# Altium schematic sheet 268f.SchDoc
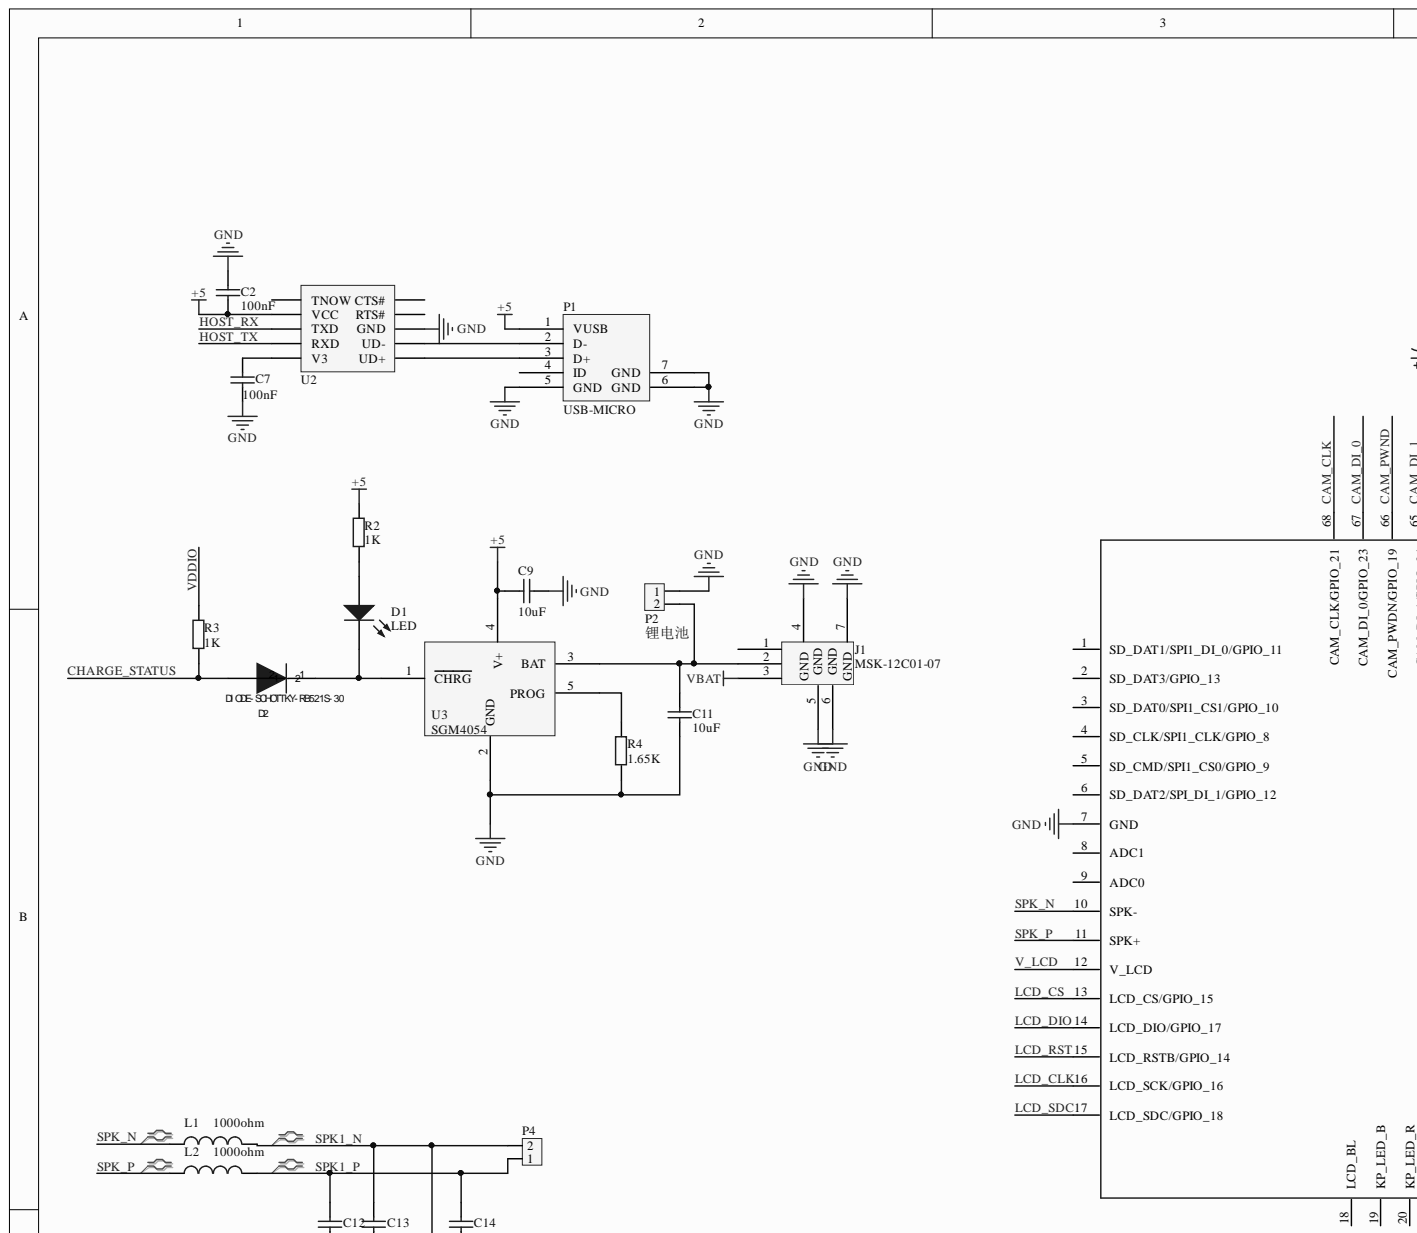
[diagram p0: 268f.SchDoc, top left]
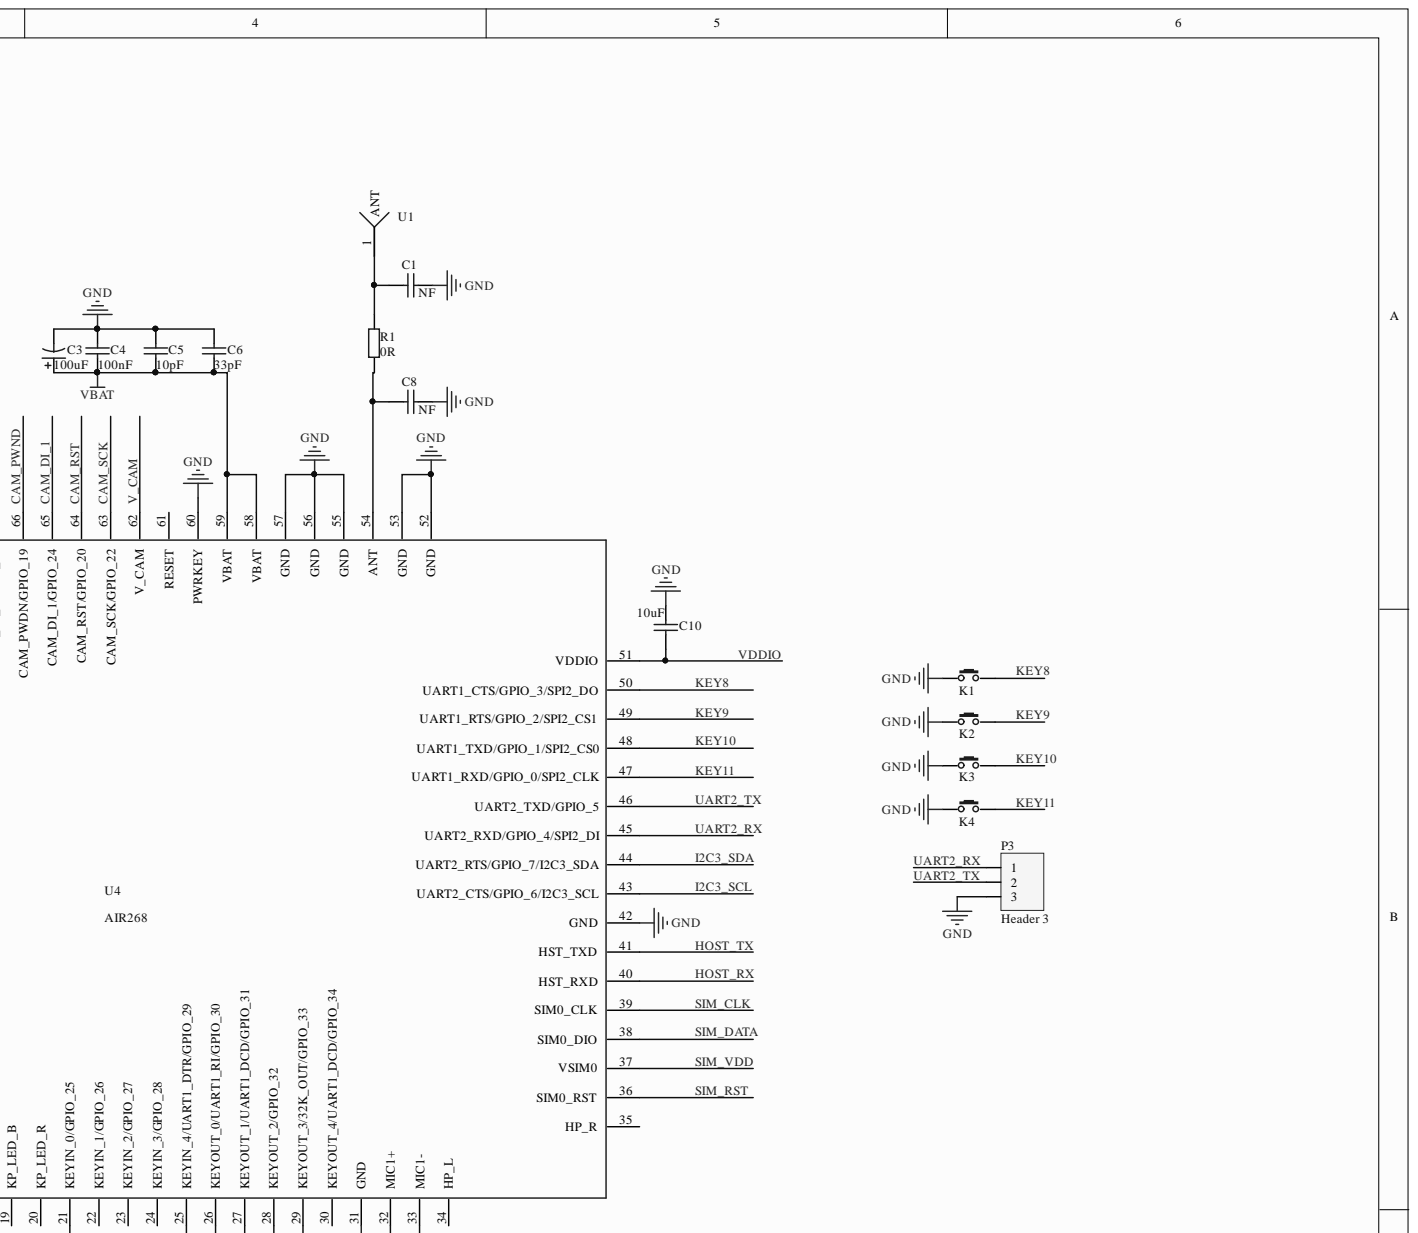
[diagram p1: 268f.SchDoc, top right]
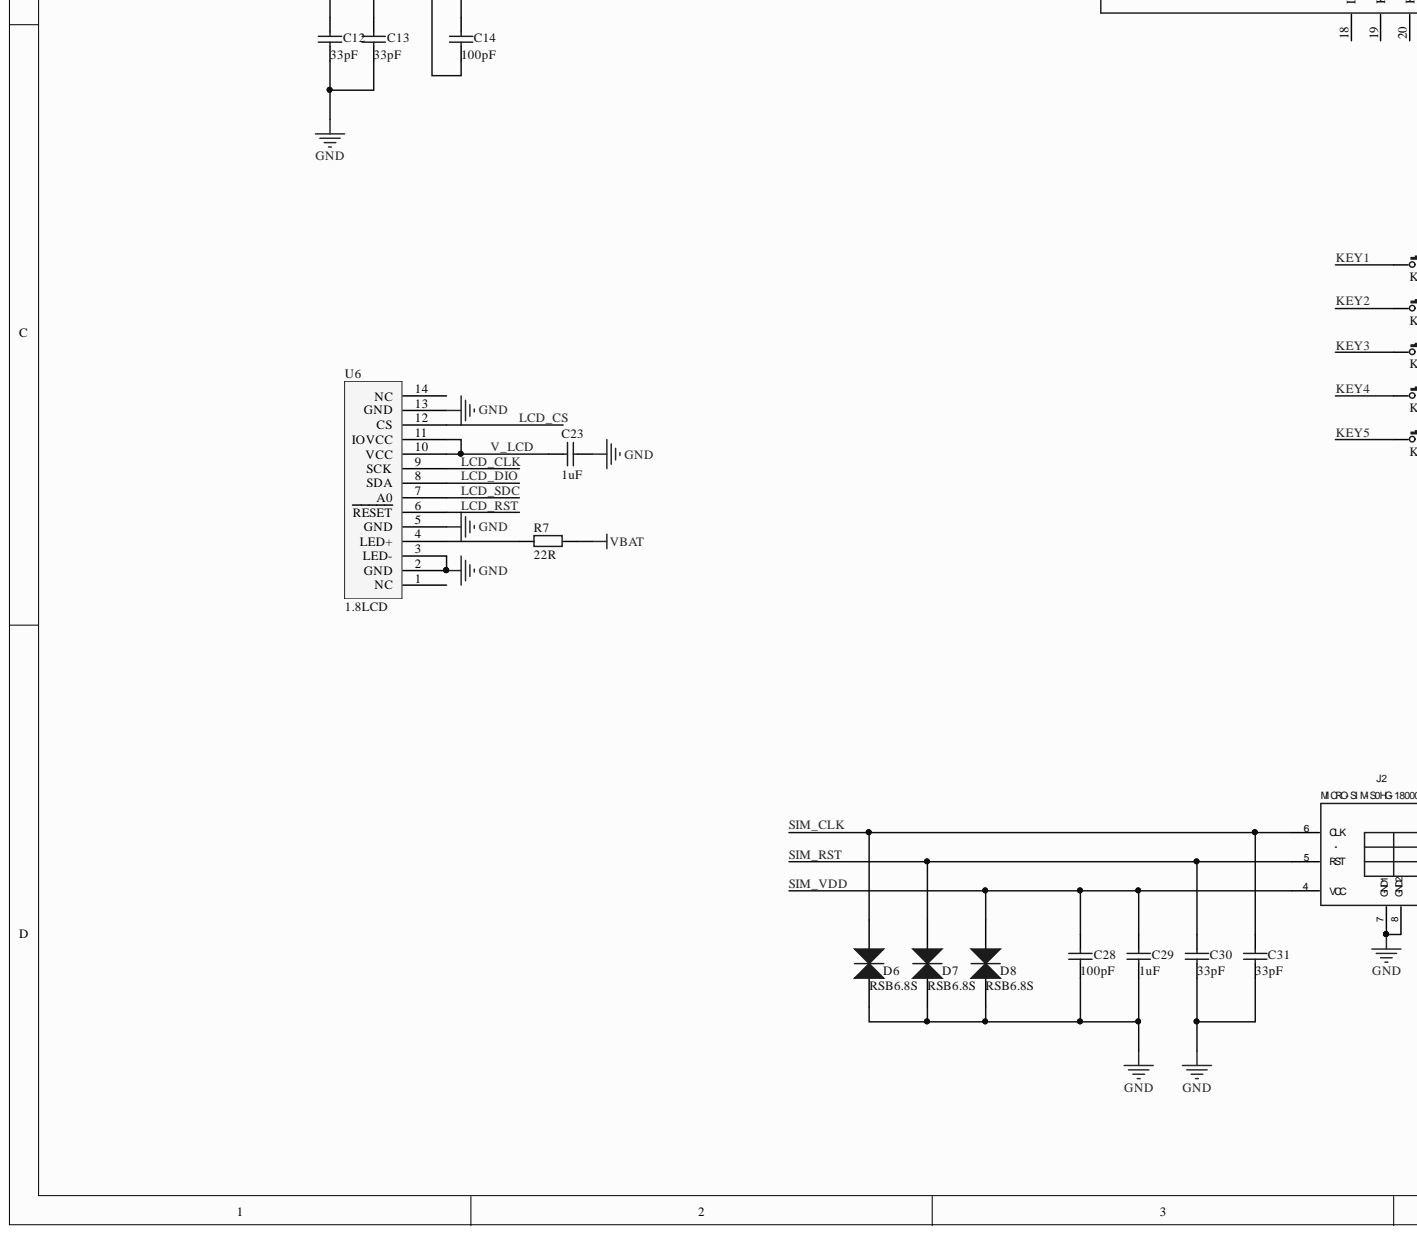
[diagram p2: 268f.SchDoc, bottom left]
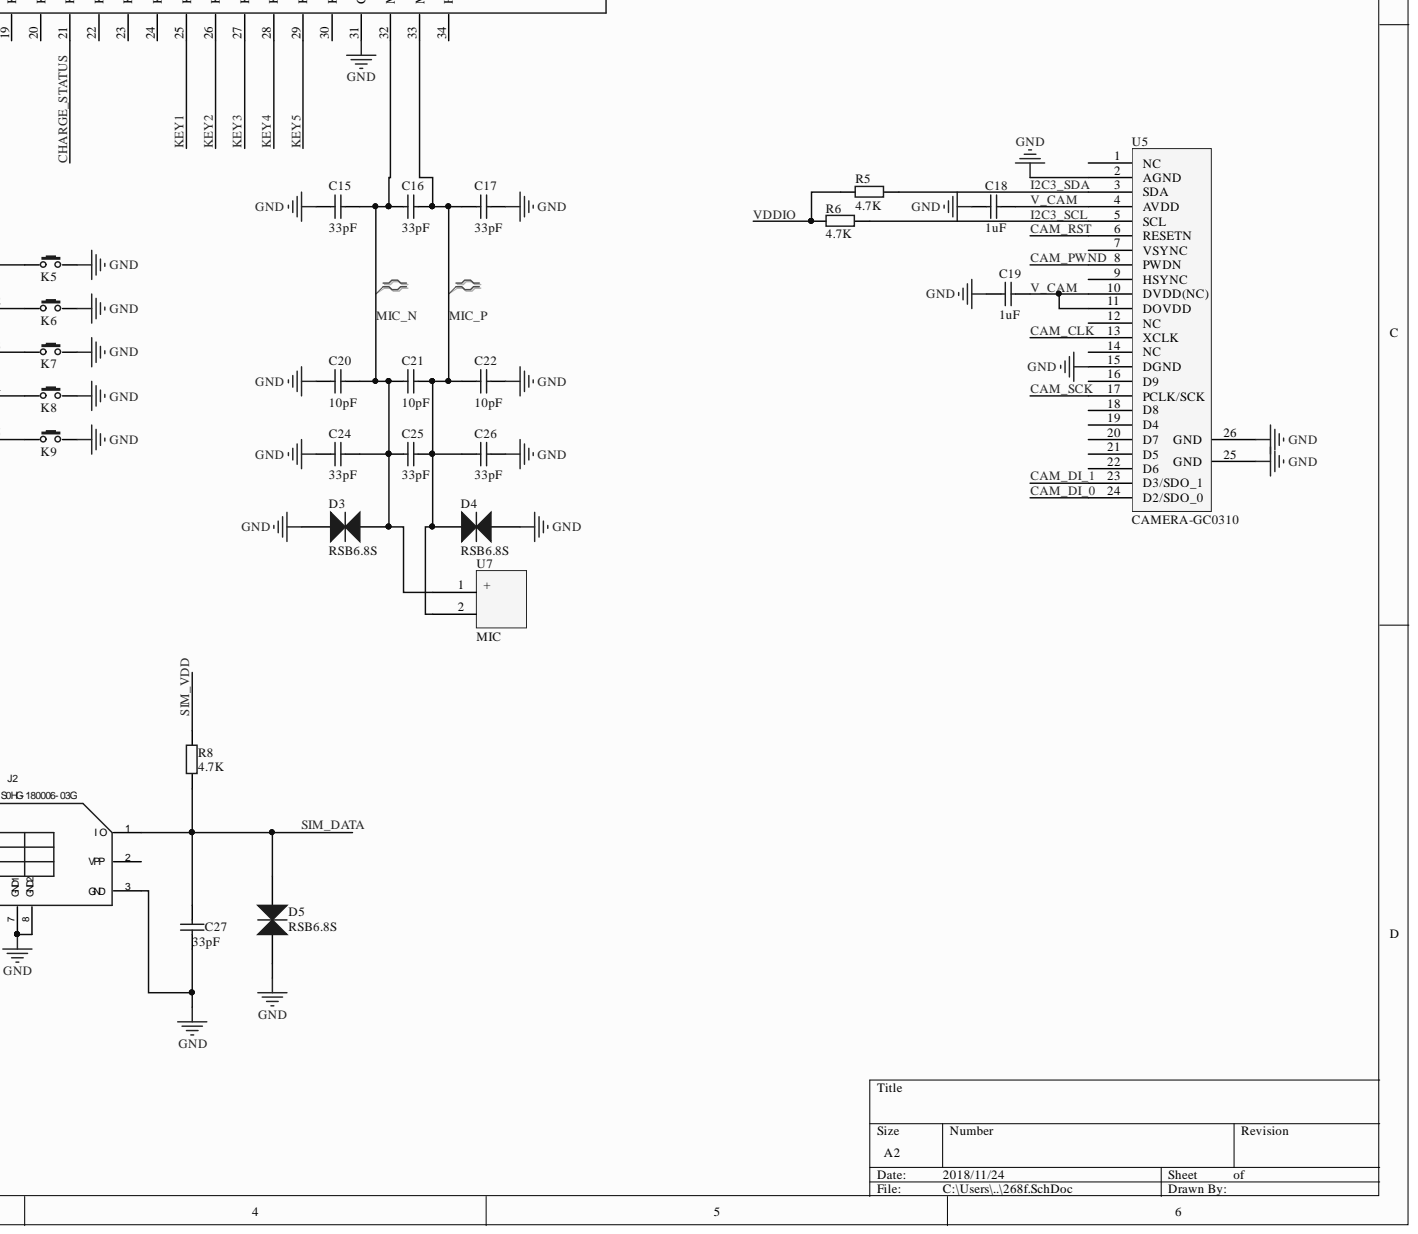
[diagram p3: 268f.SchDoc, bottom right]

## Parts
C1  value NF  [SC0402]  (hidden comment: 无极性电容)
C2  value 100nF  [SC0402]  (hidden comment: 无极性电容)
C3  value 100uF  [CAPM-B-3528X21N]  (hidden comment: 贴片铝/钽电容)
C4  value 100nF  [SC0402]  (hidden comment: 无极性电容)
C5  value 10pF  [SC0402]  (hidden comment: 无极性电容)
C6  value 33pF  [SC0402]  (hidden comment: 无极性电容)
C7  value 100nF  [SC0402]  (hidden comment: 无极性电容)
C8  value NF  [SC0402]  (hidden comment: 无极性电容)
C9  value 10uF  [SC0402]  (hidden comment: 无极性电容)
C10  value 10uF  [SC0402]  (hidden comment: 无极性电容)
C11  value 10uF  [SC0402]  (hidden comment: 无极性电容)
C12  value 33pF  [SC0402]  (hidden comment: 无极性电容)
C13  value 33pF  [SC0402]  (hidden comment: 无极性电容)
C14  value 100pF  [SC0402]  (hidden comment: 无极性电容)
C15  value 33pF  [SC0402]  (hidden comment: 无极性电容)
C16  value 33pF  [SC0402]  (hidden comment: 无极性电容)
C17  value 33pF  [SC0402]  (hidden comment: 无极性电容)
C18  value 1uF  [SC0402]  (hidden comment: 无极性电容)
C19  value 1uF  [SC0402]  (hidden comment: 无极性电容)
C20  value 10pF  [SC0402]  (hidden comment: 无极性电容)
C21  value 10pF  [SC0402]  (hidden comment: 无极性电容)
C22  value 10pF  [SC0402]  (hidden comment: 无极性电容)
C23  value 1uF  [SC0402]  (hidden comment: 无极性电容)
C24  value 33pF  [SC0402]  (hidden comment: 无极性电容)
C25  value 33pF  [SC0402]  (hidden comment: 无极性电容)
C26  value 33pF  [SC0402]  (hidden comment: 无极性电容)
C27  value 33pF  [SC0402]  (hidden comment: 无极性电容)
C28  value 100pF  [SC0402]  (hidden comment: 无极性电容)
C29  value 1uF  [SC0402]  (hidden comment: 无极性电容)
C30  value 33pF  [SC0402]  (hidden comment: 无极性电容)
C31  value 33pF  [SC0402]  (hidden comment: 无极性电容)
D1  LED  [LED_0603]
D2  DIODE-SCHOTTKY-RB521S-30  [SOD523(RB521S)]
D3  RSB6.8S  [SOD523(RB521S)]
D4  RSB6.8S  [SOD523(RB521S)]
D5  RSB6.8S  [SOD523(RB521S)]
D6  RSB6.8S  [SOD523(RB521S)]
D7  RSB6.8S  [SOD523(RB521S)]
D8  RSB6.8S  [SOD523(RB521S)]
J1  MSK-12C01-07  [MSK-12C01-07]
J2  MICRO-SIM-S0HG-180006-03G  [S0HG-180006-03G]
K1  SW-2A_1  [SW-2 贴片]  (value not drawn)
K2  SW-2A_1  [SW-2 贴片]  (value not drawn)
K3  SW-2A_1  [SW-2 贴片]  (value not drawn)
K4  SW-2A_1  [SW-2 贴片]  (value not drawn)
K5  SW-2A_1  [SW-2 贴片]  (value not drawn)
K6  SW-2A_1  [SW-2 贴片]  (value not drawn)
K7  SW-2A_1  [SW-2 贴片]  (value not drawn)
K8  SW-2A_1  [SW-2 贴片]  (value not drawn)
K9  SW-2A_1  [SW-2 贴片]  (value not drawn)
L1  value 1000ohm  [SL0402]  (hidden comment: LND)
L2  value 1000ohm  [SL0402]  (hidden comment: LND)
P1  USB-MICRO  [Micro USB]
P2  name: 锂电池  [2pad]  (hidden comment: Header 2)
P3  Header 3  [3pin-2.54插针]
P4  Header 2  [2pad]  (value not drawn)
R1  value 0R  [SC0402]  (hidden comment: 贴片电阻)
R2  value 1K  [SC0402]  (hidden comment: 贴片电阻)
R3  value 1K  [SC0402]  (hidden comment: 贴片电阻)
R4  value 1.65K  [SC0402]  (hidden comment: 贴片电阻)
R5  value 4.7K  [SC0402]  (hidden comment: 贴片电阻)
R6  value 4.7K  [SC0402]  (hidden comment: 贴片电阻)
R7  value 22R  [0603AR]  (hidden comment: 贴片电阻)
R8  value 4.7K  [SC0402]  (hidden comment: 贴片电阻)
U1  ANT-01  [ant-01]  (value not drawn)
U2  CH340E  [TSSOP50P490X110-10N]  (value not drawn)
U3  SGM4054  [SOT23-5 - duplicate]
U4  AIR268  [AIR268]
U5  CAMERA-GC0310  [FH12-24S-0.5SH]
U6  1.8LCD  [1.8LCD]
U7  MIC  [MIC]

## Nets
+5: C2.2 C9.2 P1.1(VUSB) R2.2 U2.7(VCC) U3.4(V+)
GND: C1.2 C2.1 C3.2 C4.2 C5.2 C6.2 C7.1 C8.2 C9.1 C10.2 C11.2 C12.1 C13.1 C15.2 C17.1 C18.1 C19.1 C20.1 C22.2 C23.1 C24.2 C26.1 C27.1 C28.1 C29.1 C30.1 C31.1 D3.1 D4.2 D5.1 D6.1 D7.1 D8.1 J1.4 J1.5(GND) J1.6(GND) J1.7(GND) J2.3(GND) J2.7(GND1) J2.8(GND2) K1.1 K2.1 K3.1 K4.1 K5.2 K6.2 K7.2 K8.2 K9.2 P1.5(GND) P1.6(GND) P1.7(GND) P2.1 P3.3 R4.1 U2.3(GND) U3.2(GND) U4.7(GND) U4.31(GND) U4.42(GND) U4.52(GND) U4.53(GND) U4.55(GND) U4.56(GND) U4.57(GND) U4.60(PWRKEY) U4.69 U4.70 U4.71 U4.72 U4.73 U4.74 U4.75 U5.2(AGND) U5.15(DGND) U5.25(GND) U5.26(GND) U6.2(GND) U6.3(LED-) U6.5(GND) U6.13(GND)
VBAT: C3.1 C4.1 C5.1 C6.1 J1.3 R7.1 U4.58(VBAT) U4.59(VBAT)
CAM_CLK: U4.68(CAM_CLK/GPIO_21) U5.13(XCLK)
CAM_DI_0: U4.67(CAM_DI_0/GPIO_23) U5.24(D2/SDO_0)
CAM_DI_1: U4.65(CAM_DI_1/GPIO_24) U5.23(D3/SDO_1)
CAM_PWND: U4.66(CAM_PWDN/GPIO_19) U5.8(PWDN)
CAM_RST: U4.64(CAM_RST/GPIO_20) U5.6(RESETN)
CAM_SCK: U4.63(CAM_SCK/GPIO_22) U5.17(PCLK/SCK)
CHARGE_STATUS: D2.2 R3.1 U4.21(KEYIN_0/GPIO_25)
HOST_RX: U2.8(TXD) U4.40(HST_RXD)
HOST_TX: U2.9(RXD) U4.41(HST_TXD)
I2C3_SCL: R6.1 U4.43(UART2_CTS/GPIO_6/I2C3_SCL) U5.5(SCL)
I2C3_SDA: R5.1 U4.44(UART2_RTS/GPIO_7/I2C3_SDA) U5.3(SDA)
KEY1: K5.1 U4.25(KEYIN_4/UART1_DTR/GPIO_29)
KEY2: K6.1 U4.26(KEYOUT_0/UART1_RI/GPIO_30)
KEY3: K7.1 U4.27(KEYOUT_1/UART1_DCD/GPIO_31)
KEY4: K8.1 U4.28(KEYOUT_2/GPIO_32)
KEY5: K9.1 U4.29(KEYOUT_3/32K_OUT/GPIO_33)
KEY8: K1.2 U4.50(UART1_CTS/GPIO_3/SPI2_DO)
KEY9: K2.2 U4.49(UART1_RTS/GPIO_2/SPI2_CS1)
KEY10: K3.2 U4.48(UART1_TXD/GPIO_1/SPI2_CS0)
KEY11: K4.2 U4.47(UART1_RXD/GPIO_0/SPI2_CLK)
LCD_CLK: U4.16(LCD_SCK/GPIO_16) U6.9(SCK)
LCD_CS: U4.13(LCD_CS/GPIO_15) U6.12(CS)
LCD_DIO: U4.14(LCD_DIO/GPIO_17) U6.8(SDA)
LCD_RST: U4.15(LCD_RSTB/GPIO_14) U6.6(RESET)
LCD_SDC: U4.17(LCD_SDC/GPIO_18) U6.7(A0)
MIC_N: C15.1 C16.2 C20.2 C21.1 C24.1 C25.2 D3.2 U4.32(MIC1+) U7.1
MIC_P: C16.1 C17.2 C21.2 C22.1 C25.1 C26.2 D4.1 U4.33 U7.2
SIM_CLK: C31.2 D6.2 J2.6(CLK) U4.39(SIM0_CLK)
SIM_DATA: C27.2 D5.2 J2.1(IO) R8.1 U4.38(SIM0_DIO)
SIM_RST: C30.2 D7.2 J2.5(RST) U4.36(SIM0_RST)
SIM_VDD: C28.2 C29.2 D8.2 J2.4(VCC) R8.2 U4.37(VSIM0)
SPK1_N: C13.2 C14.1 L1.2 P4.2
SPK1_P: C12.2 C14.2 L2.2 P4.1
SPK_N: L1.1 U4.10(SPK-)
SPK_P: L2.1 U4.11(SPK+)
UART2_RX: P3.1 U4.45(UART2_RXD/GPIO_4/SPI2_DI)
UART2_TX: P3.2 U4.46(UART2_TXD/GPIO_5)
V_CAM: C18.2 C19.2 U4.62(V_CAM) U5.4(AVDD) U5.10(DVDD(NC)) U5.11(DOVDD)
V_LCD: C23.2 U4.12(V_LCD) U6.10(VCC) U6.11(IOVCC)
VDDIO: C10.1 R3.2 R5.2 R6.2 U4.51(VDDIO)
NetC1_1: C1.1 R1.2 U1.1
NetC7_2: C7.2 U2.10(V3)
NetC8_1: C8.1 R1.1 U4.54(ANT)
NetC11_1: C11.1 J1.2 P2.2 U3.3(BAT)
NetD1_1: D1.1 R2.1
NetD1_2: D1.2 D2.1 U3.1(CHRG)
NetP1_2: P1.2(D-) U2.2(UD-)
NetP1_3: P1.3(D+) U2.1(UD+)
NetR4_2: R4.2 U3.5(PROG)
NetR7_2: R7.2 U6.4(LED+)

Not connected: J1 1; J2 2(VPP); P1 4(ID); U2 4(RTS#) 5(CTS#) 6(TNOW); U4 1(SD_DAT1/SPI1_DI_0/GPIO_11) 2(SD_DAT3/GPIO_13) 3(SD_DAT0/SPI1_CS1/GPIO_10) 4(SD_CLK/SPI1_CLK/GPIO_8) 5(SD_CMD/SPI1_CS0/GPIO_9) 6(SD_DAT2/SPI_DI_1/GPIO_12) 8(ADC1) 9(ADC0) 18(LCD_BL) 19(KP_LED_B) 20(KP_LED_R) 22(KEYIN_1/GPIO_26) 23(KEYIN_2/GPIO_27) 24(KEYIN_3/GPIO_28) 30(KEYOUT_4/UART1_DCD/GPIO_34) 34(HP_L) 35(HP_R) 61(RESET); U5 1(NC) 7(VSYNC) 9(HSYNC) 12(NC) 14(NC) 16(D9) 18(D8) 19(D4) 20(D7) 21(D5) 22(D6); U6 1(NC) 14(NC)

## Library data of the parts
C1 C2 C4-C31 = 无极性电容: Capacitor; Component Kind: Standard; LatestRevisionDate: 17-Jul-2002; LatestRevisionNote: Re-released for DXP Platform.; Library Name: Schlib1.SchLib; PackageDescription: Radial Cap, Thru-Hole; 2 Leads; 0.3 in Pin Spacing; PackageReference: RAD-0.3; Published: 8-Jun-2000; Publisher: Altium Limited
C3 = 贴片铝/钽电容: Polarized Capacitor (Axial); Component Kind: Standard; LatestRevisionDate: 17-Jul-2002; LatestRevisionNote: Re-released for DXP Platform.; Library Name: Schlib1.SchLib; PackageDescription: Polarized Capacitor; 2 Leads; PackageReference: POLAR0.8; Published: 8-Jun-2000; Publisher: Altium Limited
D1 = LED-贴片-直插: Component Kind: Standard; Library Name: Schlib1.SchLib
D2 = DIODE-SCHOTTKY-RB521S-30: Schottky Diode;30V;200mA/0.6V;SOD-523;0.7mm;ROHS; Manufacture 1: Panjit;WILL;KEC;Onsemi;; Manufacture 1 P/N: RB521S30T1G;KDR730E;RB521S30-2/TR;RB521S30/TR; Serial Number: 81210000004; Component Kind: Standard; Geometry.Height: 0.7mm; Library Name: Schlib1.SchLib; PCB DECAL: SOD523(RB521S)
D3-D8 = TVS: Component Kind: Standard; Library Name: Schlib1.SchLib
J2 = MICRO-SIM-S0HG-180006-03G: 1.8H掀盖 Micro SIM卡座;16.3*14.66*1.8mm; Manufacture 1: 深圳市精睿兴业科技有限公司; Geometry.Height: 1.8mm; Component Kind: Standard; Library Name: Schlib2.SchLib; Manufacture 1 P/N: S0HG-180006-03G; PCB DECAL: S0HG-180006-03G
L1 L2 = LND: Bead;1000ohm;250mA;0402;0.6mm;ROHS; Component Kind: Standard; Library Name: Schlib2.SchLib
  L2: Value: 1000ohm
P1 = USB-micro: MINUSB; Component Kind: Standard; Library Name: Schlib1.SchLib
P2 P4 = Header 2_1: DIP 2.54; Component Kind: Standard; Library Name: Schlib1.SchLib
P3 = Header 3: Header, 3-Pin; Component Kind: Standard; LatestRevisionDate: 17-Jul-2002; LatestRevisionNote: Re-released for DXP Platform.; Library Name: Schlib1.SchLib; Publisher: Altium Limited
R1-R8 = 贴片电阻: Resistor; Component Kind: Standard; LatestRevisionDate: 17-Jul-2002; LatestRevisionNote: Re-released for DXP Platform.; Library Name: Schlib1.SchLib; PackageDescription: Axial Device, Thru-Hole; 2 Leads; 0.4 in Pin Spacing; PackageReference: AXIAL-0.4; Published: 8-Jun-2000; Publisher: Altium Limited
U4 = AIR268: Geometry.Height: 2.5 mm; Component Kind: Standard; Library Name: Schlib1.SchLib; PCB DECAL: AIR268
J1 = MSK-12C01-07: Component Kind: Standard; Library Name: Schlib1.SchLib
K1-K9 = SW-2A_1: Component Kind: Standard; Library Name: Schlib1.SchLib
U1 = ANT-01: Component Kind: Standard; Library Name: Schlib1.SchLib; PCB DECAL: ANT-01
U2 = CH340E: Component Kind: Standard; Library Name: Schlib1.SchLib
U3 = SGM4054: Component Kind: Standard; Library Name: Schlib1.SchLib
U5 = CAMERA-GC0310: Component Kind: Standard; Library Name: Schlib1.SchLib
U6 = 1.8LCD: Component Kind: Standard; Library Name: Schlib1.SchLib
U7 = MIC: Component Kind: Standard; Library Name: Schlib1.SchLib
